# Altium schematic sheet 03_Power_a_Connector.SchDoc
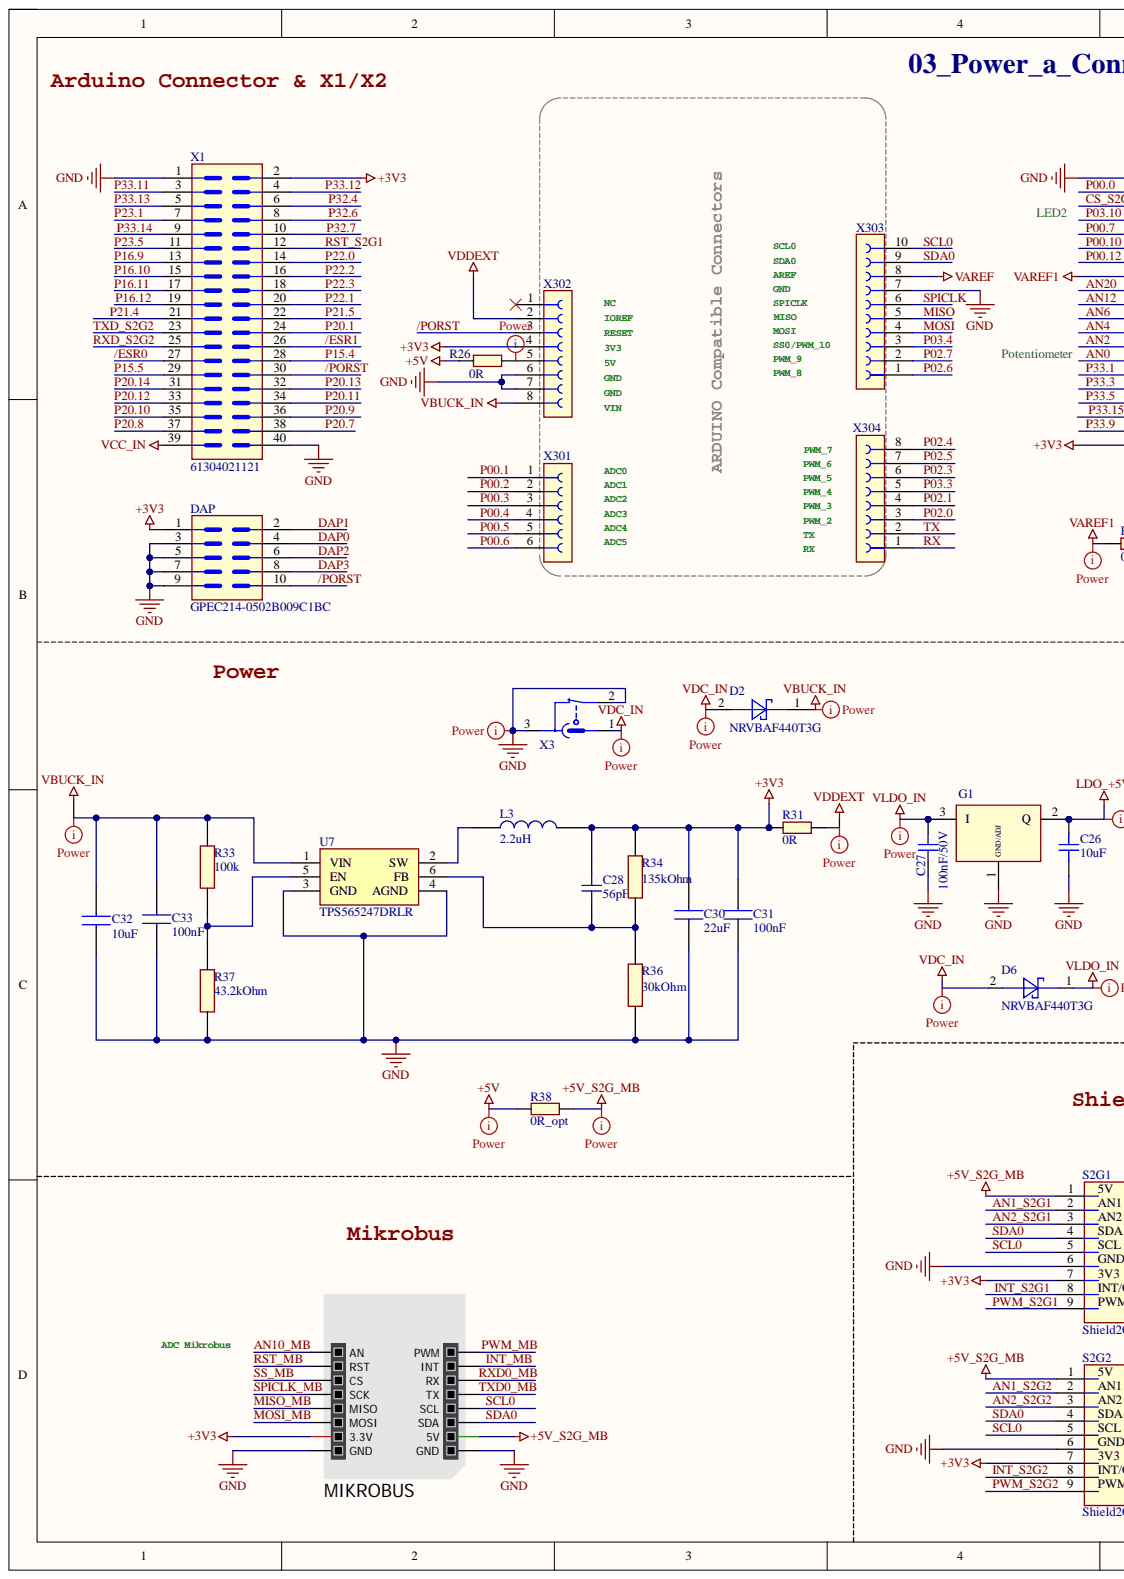
[diagram p0: 03_Power_a_Connector.SchDoc, left]
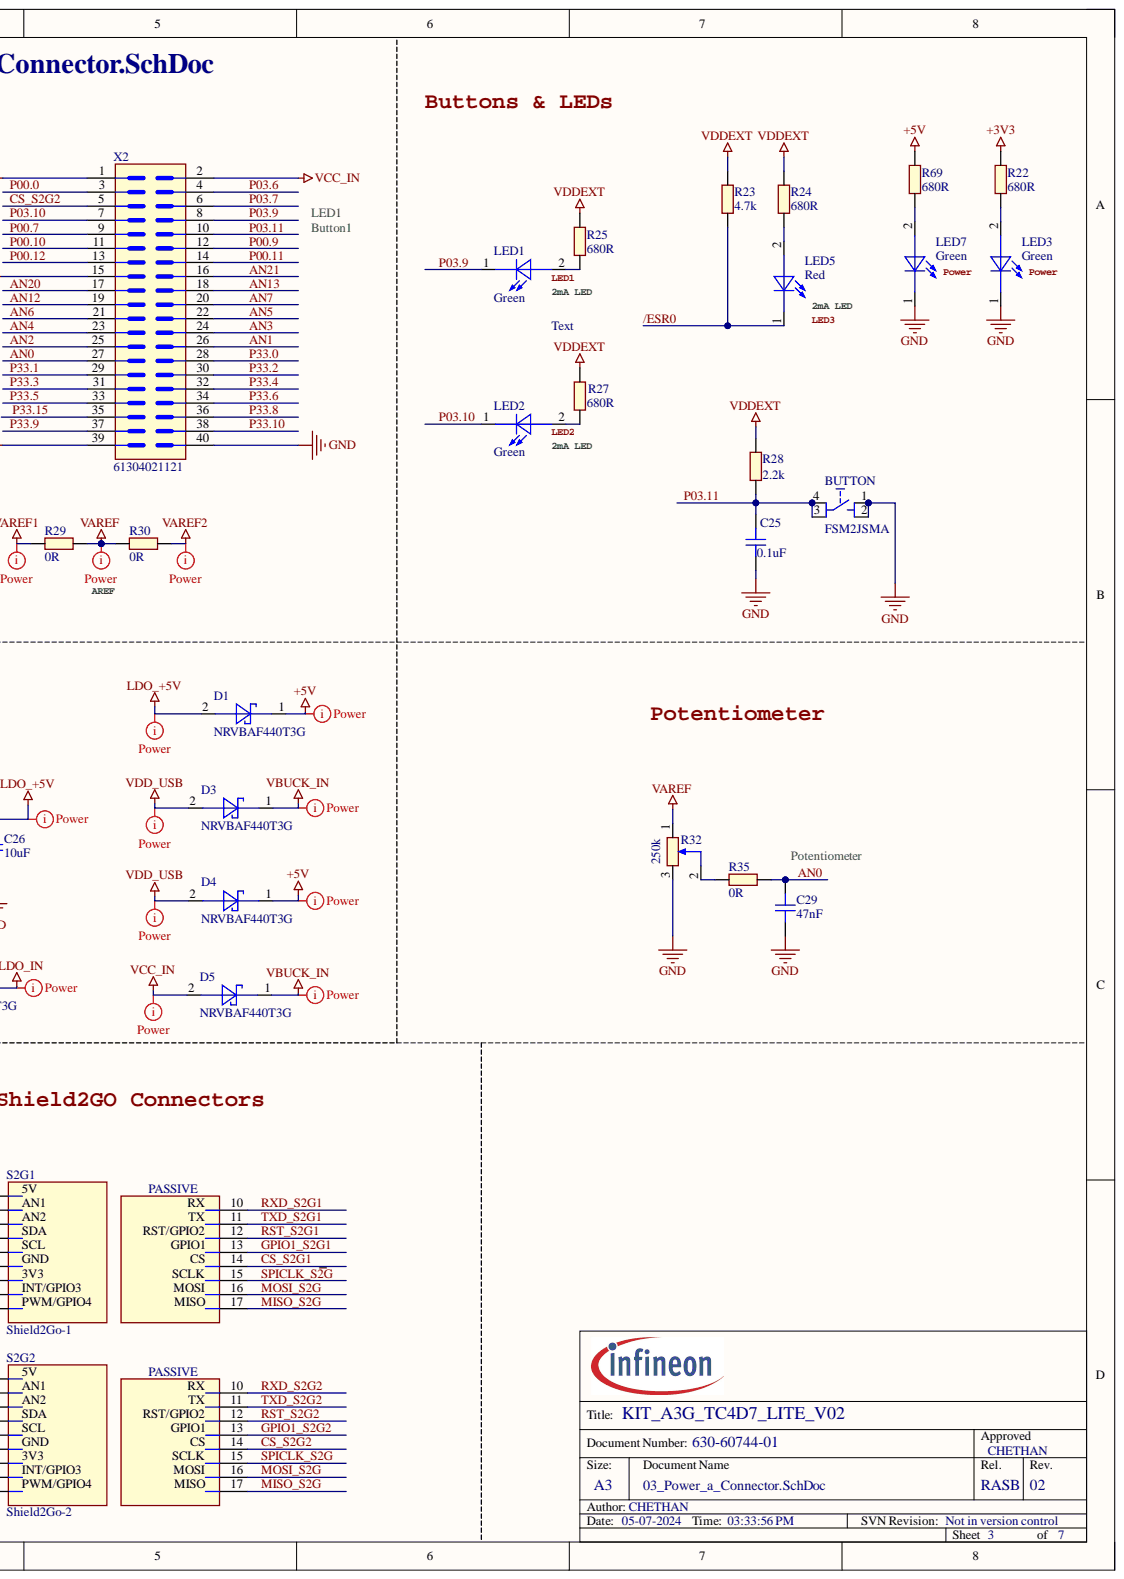
[diagram p1: 03_Power_a_Connector.SchDoc, right]

## Parts
BUTTON  FSM2JSMA  [FSM2JSMA]
C25  0.1uF  [CAPC1005X55N-1]
C26  10uF  [CAPC1005X70N]
C27  100nF/50V  [CAPC1608X90N-4]
C28  56pF  [CAPC1005X55N-0]
C29  47nF  [CAPC1608X90N-4]
C30  22uF  [CAPC2012X145N]
C31  100nF  [CAPC1608X90N]
C32  10uF  [CAPC2012X145N_1]
C33  100nF  [CAPC1608X90N]
D1  NRVBAF440T3G  [SODFL5126X110N]
D2  NRVBAF440T3G  [SODFL5126X110N]
D3  NRVBAF440T3G  [SODFL5126X110N]
D4  NRVBAF440T3G  [SODFL5126X110N]
D5  NRVBAF440T3G  [SODFL5126X110N]
D6  NRVBAF440T3G  [SODFL5126X110N]
DAP  GPEC214-0502B009C1BC  [CON-M-SMD-GPEC214-0502B009C1BC]
G1  TLE4284DV50ATMA1  [INF-PG-TO252-3-11_N]  (value not drawn)
L3  2.2uH  [INDPM6965X300N]
LED1  Green  Type: LED  [LED-SMD-LG L29K-XXXX-24]
LED2  Green  Type: LED  [LED-SMD-LG L29K-XXXX-24]
LED3  Green  [LED-SMD-LG L29K-XXXX-24]
LED5  Red  Type: LED  [LEDSC160X80X80-2N]
LED7  Green  [LED-SMD-LG L29K-XXXX-24]
MIKROBUS  MIKROBUS HOST CONN.  [MIKROBUS HOST CONN.]  (value not drawn)
R22  680R  [RESC1005X40N]
R23  4.7k  [RESC1005X40N-1]
R24  680R  [RESC1005X40N]
R25  680R  [RESC1005X40N]
R26  0R  [RESC1609X50N]
R27  680R  [RESC1005X40N]
R28  2.2k  [RESC1005X40N]
R29  0R  [RESC1609X50N]
R30  0R  [RESC1609X50N]
R31  0R  [RESC1609X50N]
R32  250k  [TRIM_3352T-1-254LF]
R33  100k  [RESC1005X40N]
R34  135kOhm  [RESC1608X55N]
R35  0R  [RESC1609X50N]
R36  30kOhm  [RESC1608X55N]
R37  43.2kOhm  [RESC1005X40N]
R38  0R_opt  [RESC1609X50N]
R69  680R  [RESC1005X40N]
S2G1  Shield2Go-1  [Shield2Go_S2G_1P]  (no BOM)
S2G2  Shield2Go-2  [Shield2Go_S2G_1P]  (no BOM)
U7  TPS565247DRLR  [SOTFL50P160X60-6N]
X1  61304021121  [CON-M-THT-68691-440HLF]
X2  61304021121  [CON-M-THT-68691-440HLF]
X3  con_pwr13_ncc32_3p-A  [CON-THT-JACK-FC681465P]  (value not drawn)
X301  Socket 6  [CON-F-THT-SSQ-106-03-G-S]  (value not drawn)
X302  Socket 8  [CON-F-THT-PPTC081LFBN-RC]  (value not drawn)
X303  PPTC101LFBN-RC  [CON-F-THT-SSQ-110-03-F-S]  (value not drawn)
X304  Socket 8  [CON-F-THT-PPTC081LFBN-RC]  (value not drawn)

## Nets
+3V3: C28.2 C30.2 C31.2 DAP.1 L3.2 MIKROBUS.7(3.3V) R22.2 R31.1 R34.2 S2G1.7 S2G2.7 X1.2 X2.39 X302.4
+5V: D1.1 D4.1 R26.1 R38.1 R69.2
+5V_S2G_MB: MIKROBUS.10(5V) R38.2 S2G1.1 S2G2.1
GND: BUTTON.1 BUTTON.2 C25.1 C26.1 C27.2 C29.1 C30.1 C31.1 C32.1 C33.1 DAP.3 DAP.5 DAP.7 DAP.9 G1.1 LED3.1 LED7.1 MIKROBUS.8(GND) MIKROBUS.9(GND) R32.3 R36.1 R37.1 S2G1.6 S2G2.6 U7.3(GND) U7.4(AGND) X1.1 X1.40 X2.1 X2.40 X3.2 X3.3 X302.6 X302.7 X303.7
LDO_+5V: C26.2 D1.2 G1.2
VAREF: R29.1 R30.1 R32.1 X303.8
VAREF1: R29.2 X2.15
VAREF2: R30.2
VBUCK_IN: C32.2 C33.2 D2.1 D3.1 D5.1 R33.2 U7.1(VIN) X302.8
VCC_IN: D5.2 X1.39 X2.2
VDC_IN: D2.2 D6.2 X3.1
VDD_USB: D3.2 D4.2
VDDEXT: R23.2 R24.1 R25.2 R27.2 R28.2 R31.2 X302.2
VLDO_IN: C27.1 D6.1 G1.3
/ESR0: LED5.1 R23.1 X1.27
/ESR1: X1.26
/PORST: DAP.10 X1.30 X302.3
AN0: C29.2 R35.2 X2.27
AN1: X2.26
AN1_S2G1: S2G1.2
AN1_S2G2: S2G2.2
AN2: X2.25
AN2_S2G1: S2G1.3
AN2_S2G2: S2G2.3
AN3: X2.24
AN4: X2.23
AN5: X2.22
AN6: X2.21
AN7: X2.20
AN10_MB: MIKROBUS.1(AN)
AN12: X2.19
AN13: X2.18
AN20: X2.17
AN21: X2.16
CS_S2G1: S2G1.14
CS_S2G2: S2G2.14 X2.5
DAP0: DAP.4
DAP1: DAP.2
DAP2: DAP.6
DAP3: DAP.8
GPIO1_S2G1: S2G1.13
GPIO1_S2G2: S2G2.13
INT_MB: MIKROBUS.15(INT)
INT_S2G1: S2G1.8
INT_S2G2: S2G2.8
MISO: X303.5
MISO_MB: MIKROBUS.5(MISO)
MISO_S2G: S2G1.17 S2G2.17
MOSI: X303.4
MOSI_MB: MIKROBUS.6(MOSI)
MOSI_S2G: S2G1.16 S2G2.16
P00.0: X2.3
P00.1: X2.11 X301.1  [also labelled P00.10]
P00.2: X301.2
P00.3: X301.3
P00.4: X301.4
P00.5: X301.5
P00.6: X301.6
P00.7: X2.9
P00.9: X2.12
P00.11: X2.14
P00.12: X2.13
P02.0: X304.3
P02.1: X304.4
P02.3: X304.6
P02.4: X304.8
P02.5: X304.7
P02.6: X303.1
P02.7: X303.2
P03.3: X304.5
P03.4: X303.3
P03.6: X2.4
P03.7: X2.6
P03.9: LED1.1 X2.8
P03.10: LED2.1 X2.7
P03.11: BUTTON.3 BUTTON.4 C25.2 R28.1 X2.10
P15.4: X1.28
P15.5: X1.29
P16.9: X1.13
P16.10: X1.15
P16.11: X1.17
P16.12: X1.19
P20.1: X1.24 X1.35  [also labelled P20.10]
P20.7: X1.38
P20.8: X1.37
P20.9: X1.36
P20.11: X1.34
P20.12: X1.33
P20.13: X1.32
P20.14: X1.31
P21.4: X1.21
P21.5: X1.22
P22.0: X1.14
P22.1: X1.20
P22.2: X1.16
P22.3: X1.18
P23.1: X1.7
P23.5: X1.11
P32.4: X1.6
P32.6: X1.8
P32.7: X1.10
P33.0: X2.28
P33.1: X2.29 X2.38  [also labelled P33.10]
P33.2: X2.30
P33.3: X2.31
P33.4: X2.32
P33.5: X2.33
P33.6: X2.34
P33.8: X2.36
P33.9: X2.37
P33.11: X1.3
P33.12: X1.4
P33.13: X1.5
P33.14: X1.9
P33.15: X2.35
PWM_MB: MIKROBUS.16(PWM)
PWM_S2G1: S2G1.9
PWM_S2G2: S2G2.9
RST_MB: MIKROBUS.2(RST)
RST_S2G1: S2G1.12 X1.12
RST_S2G2: S2G2.12
RX: X304.1
RXD0_MB: MIKROBUS.14(RX)
RXD_S2G1: S2G1.10
RXD_S2G2: S2G2.10 X1.25
SCL0: MIKROBUS.12(SCL) S2G1.5 S2G2.5 X303.10
SDA0: MIKROBUS.11(SDA) S2G1.4 S2G2.4 X303.9
SPICLK: X303.6
SPICLK_MB: MIKROBUS.4(SCK)
SPICLK_S2G: S2G1.15 S2G2.15
SS_MB: MIKROBUS.3(CS)
TX: X304.2
TXD0_MB: MIKROBUS.13(TX)
TXD_S2G1: S2G1.11
TXD_S2G2: S2G2.11 X1.23
NetC28_1: C28.1 R34.1 R36.2 U7.6(FB)
NetL3_1: L3.1 U7.2(SW)
NetLED1_2: LED1.2 R25.1
NetLED2_2: LED2.2 R27.1
NetLED3_2: LED3.2 R22.1
NetLED5_2: LED5.2 R24.2
NetLED7_2: LED7.2 R69.1
NetR26_2: R26.2 X302.5
NetR32_2: R32.2 R35.1
NetR33_1: R33.1 R37.2 U7.5(EN)

Not connected: X302 1

## Library data of the parts
BUTTON = 376475: Tactile Switch SPST-NO Top Actuated Surface Mount; Legacy PartNumber: SW0000003; Manufacturer: TE Connectivity ALCOSWITCH Switches; Manufacturer Component Status: Active; Automotive Qualified: No; Legacy DeviceID: TEC_FSM2JSMA; Second Source allowed: Yes; Style: Not Applicable; Version Number: V01; Voltage DC (max): na; Article Number: 376475; Component Kind: Standard; ComponentLink1Description: Vendor WebSite; ComponentLink1URL: http://www.tycoelectronics.com/; ComponentLink2Description: Datasheet; ComponentLink2URL: http://webnetprod.muc.infineon.com/pcbportal_prod/WorkFlows/AltiumComponent/StandardDocumentation?Versionid=376476; Manufacturer Order Number: FSM2JSMA; Package Description: Switch,Push button ,4.5mm Pitch,Vertical, 6mm L X 6mm W X 5.4mm H body; Part Type: Electro Mechanic; PLMStatus: Active; RoHS Compliant: Y; Supplier 1: Farnell; Supplier 2: DigiKey; Supplier 3: Mouser; Supplier Part Number 1: 3801287; Supplier Part Number 2: 450-1130-ND; Supplier Part Number 3: 506-FSM2JSMA; Temperature max: 85; Temperature min: -35; Temperature Range: Military; Value: FSM2JSMA; Library Name: AURIX_Lite_Kit_V2.0.SCHLIB
C25 = 308680: 0.1 µF ±10% 16V Ceramic Capacitor X7R 0402 (1005 Metric); Manufacturer: TDK Corporation; Manufacturer Component Status: Active; Manufacturer Order Number: CGA2B1X7R1C104K050BC; Multiple Parts: No; Alternate View: Yes; Automotive Qualified: AEC Q200; Combined Parameters: TC:X7R (EIA);Tol.:-10%/+10%;Vdc:16V; Legacy DeviceID: CAP01010669; Package Imperial: 0402; Package Metric: 1005; QuickPick: 100nF / 16V / 10% / X7R (EIA) / 0402 (1005); Style: Single; Symbol Type: Capacitor; Single; Standard; 2 Pins; Type: Standard; Value: 100nF; Version Number: V01; Article Number: 308680; Component Kind: Standard; ComponentLink1Description: Vendor WebSite; ComponentLink1URL: http://www.tdk.com; ComponentLink2Description: Datasheet; ComponentLink2URL: http://webnetprod.muc.infineon.com/PCBPortal_Prod/WorkFlows/AltiumComponent/StandardDocumentation?Versionid=308681; Legacy PartNumber: CAP01010669; Package Description: Capacitor,non-polarized,Chip;1.00mm L X 0.50mm W X 0.55mm H; PackageReference: 0402 (1005); Part Type: Capacitor; PLMStatus: Active; ROHS Compliant: Y; Second Source allowed: Yes; Supplier 1: Farnell; Supplier 2: DigiKey; Supplier 3: Mouser; Supplier Part Number 1: 2458205; Supplier Part Number 2: 445-5613-1-ND; Supplier Part Number 3: 810-CGA2B1X7R1C104K; Technology: Ceramic; Temperature max: 125; Temperature min: -55; Temperature Range: Military; Tolerance Neg: 10%; Tolerance Pos: 10%; Voltage DC (max): 16V; Library Name: AURIX_Lite_Kit_V2.0.SCHLIB
C26 = 310655: 10 µF ±20% 10V Ceramic Capacitor X5R 0402 (1005 Metric); Manufacturer: Samsung; Manufacturer Component Status: Active; Manufacturer Order Number: CL05A106MP5NUNC; Alternate View: Yes; Automotive Qualified: No; Combined Parameters: TC:X5R (EIA);Tol.:-20%/+20%;Vdc:10V; Legacy DeviceID: CAP01010925; Package Imperial: 0402; Package Metric: 1005; QuickPick: 10uF / 10V / 20% / X5R (EIA) / 0402; Style: Single; Symbol Type: Capacitor; Single; Standard; 2 Pins; Type: Standard; Version Number: V01; Article Number: 310655; Component Kind: Standard; ComponentLink1Description: Vendor WebSite; ComponentLink1URL: http://www.samsungsem.co.kr/; ComponentLink2Description: Datasheet; ComponentLink2URL: http://webnetprod.muc.infineon.com/PCBPortal_Prod/WorkFlows/AltiumComponent/StandardDocumentation?Versionid=310656; Legacy PartNumber: CAP01010925; Multiple Parts: No; Package Description: Capacitor,non-polarized,Chip;1.00mm L X 0.50mm W X 0.70mm H; PackageReference: 0402; Part Type: Capacitor; PLMStatus: Active; ROHS Compliant: Y; Second Source allowed: Yes; Supplier 1: Farnell; Supplier 2: DigiKey; Supplier 3: Mouser; Supplier Part Number 2: 1276-1450-1-ND; Supplier Part Number 3: Not Assigned; Technology: Ceramic; Temperature max: 85; Temperature min: -55; Temperature Range: Military; Tolerance Neg: 20%; Tolerance Pos: 20%; Value: 10uF; Voltage DC (max): 10V; Library Name: AURIX_Lite_Kit_V2.0.SCHLIB
C27 = 497792: 0.1 µF ±20% 100V Ceramic Capacitor X7S 0603 (1608 Metric); Manufacturer: TDK Corporation; Manufacturer Order Number: CGA3E3X7S2A104M080AB; Multiple Parts: No; Alternate View: Yes; Automotive Qualified: AEC Q200; Combined Parameters: TC:X7S (EIA);Tol.:-20%/+20%;Vdc:100V; Package Imperial: 0603; Package Metric: 1608; PLMStatus: Active; QuickPick: 100nF / 100V / 20% / X7S (EIA) / 0603; Style: Single; Symbol Type: Capacitor; Single; Standard; 2 Pins; Type: Standard; Value: 100nF; Version Number: V01; Application: Standard; Article Number: 497792; Component Kind: Standard; ComponentLink1Description: Vendor WebSite; ComponentLink1URL: http://www.tdk.com; ComponentLink2Description: Datasheet; ComponentLink2URL: http://webnetprod.muc.infineon.com/PCBPortal_Prod/WorkFlows/AltiumComponent/StandardDocumentation?Versionid=497793; Package Description: Capacitor,non-polarized,Chip;1.60mm L X 0.80mm W X 0.90mm H; PackageReference: 0603; Part Type: Capacitor; ROHS Compliant: Y; Second Source allowed: Yes; Supplier 1: Farnell; Supplier 2: DigiKey; Supplier 3: Mouser; Supplier Part Number 1: 2803337; Supplier Part Number 2: 445-12576-1-ND; Supplier Part Number 3: 810-CGA3E3X7S2A104AB; Technology: Ceramic; Temperature max: 125; Temperature min: -55; Temperature Range: Military; Tolerance Neg: 20%; Tolerance Pos: 20%; Voltage DC (max): 100V; Library Name: AURIX_Lite_Kit_V2.0.SCHLIB
C28 = 289241: 56 pF ±5% 50V Ceramic Capacitor C0G, NP0 0402 (1005 Metric); Manufacturer: Taiyo Yuden; Manufacturer Order Number: UMK105CG560JV-F; Multiple Parts: No; Alternate View: Yes; Automotive Qualified: AEC Q200; Combined Parameters: TC:X7R (EIA);Tol.:-10%/+10%;Vdc:25V; ComponentStatus: Released; Legacy DeviceID: CAP01006921; Package Imperial: 0402; Package Metric: 1005; PLMStatus: Active; QuickPick: CAP CER 56PF 50V C0G/NP0 0402; Style: Single; Symbol Type: Capacitor; Single; Standard; 2 Pins; Type: Standard; Version Number: V01; Application: Standard; Article Number: 289241; Component Kind: Standard; ComponentLink1Description: Vendor WebSite; ComponentLink1URL: http://www.murata.com/; ComponentLink2Description: Datasheet; ComponentLink2URL: http://webnetprod.muc.infineon.com/PCBPortal_Prod/WorkFlows/AltiumComponent/StandardDocumentation?Versionid=289242; Legacy PartNumber: CAP01006921; Library Name: TriBoard_TC4X7_COM_V20.SCHLIB; Package Description: Capacitor,non-polarized,Chip;1.00mm L X 0.50mm W X 0.55mm H; PackageReference: CAPC1005X55N-0; Part Type: Capacitor; ROHS Compliant: Y; Second Source allowed: Yes; Supplier 1: Farnell; Supplier 2: DigiKey; Supplier 3: Mouser; Supplier Part Number 2: 587-1208-1-ND; Technology: Ceramic; Temperature max: 125; Temperature min: -55; Temperature Range: Military; Tolerance Neg: 5%; Tolerance Pos: 5%; Value: 56pF; Voltage DC (max): 50V
C29 = 309989: 0.047 µF ±10% 50V Ceramic Capacitor X7R 0603 (1608 Metric); Manufacturer: TDK Corporation; Manufacturer Component Status: Active; Manufacturer Order Number: CGA3E2X7R1H473K080AA; Alternate View: Yes; Automotive Qualified: No; Combined Parameters: TC:X8R (EIA);Tol.:-10%/+10%;Vdc:50V; Legacy DeviceID: CAP01010836; Package Imperial: 0603; Package Metric: 1608; QuickPick: 47nF / 50V / 10% / X8R (EIA) / 0603 (1608); Style: Single; Symbol Type: Capacitor; Single; Standard; 2 Pins; Type: Standard; Version Number: V01; Article Number: 309989; Component Kind: Standard; ComponentLink1Description: Vendor WebSite; ComponentLink1URL: http://www.tdk.com; ComponentLink2Description: Datasheet; ComponentLink2URL: http://webnetprod.muc.infineon.com/PCBPortal_Prod/WorkFlows/AltiumComponent/StandardDocumentation?Versionid=309990; Legacy PartNumber: CAP01010836; Multiple Parts: No; Package Description: Capacitor,non-polarized,Chip;1.60mm L X 0.80mm W X 0.90mm H; PackageReference: 0603 (1608); Part Type: Capacitor; PLMStatus: Active; ROHS Compliant: Y; Second Source allowed: Yes; Supplier 1: Farnell; Supplier 2: DigiKey; Supplier 3: Mouser; Supplier Part Number 1: 2354114; Supplier Part Number 2: 445-5664-1-ND; Supplier Part Number 3: 810-C1608X8R1H473K; Technology: Ceramic; Temperature max: 150; Temperature min: -55; Temperature Range: Military; Tolerance Neg: 10%; Tolerance Pos: 10%; Value: 47nF; Voltage DC (max): 50V; Library Name: AURIX_Lite_Kit_V2.0.SCHLIB
C30 = GRM21BR61A226ME44L: 22 µF ±20% 10V Ceramic Capacitor X5R 0805 (2012 Metric); Manufacturer: Murata Electronics; Geometry.Height: 1.45mm; Arrow Part Number: GRM21BR61A226ME44L; Arrow Price/Stock: https://www.arrow.com/en/products/grm21br61a226me44l/murata-manufacturing?region=nac; Component Kind: Standard; Datasheet Link: https://datasheet.datasheetarchive.com/originals/distributors/DKDS-10/192043.pdf; Library Name: GRM21BR61A226ME44L.SchLib; Manufacturer Order Number: GRM21BR61A226ME44L; Manufacturer_Name: Murata Electronics; Manufacturer_Part_Number: GRM21BR61A226ME44L; Mouser Part Number: 81-GRM21BR61A226ME4L; Mouser Price/Stock: https://www.mouser.co.uk/ProductDetail/Murata-Electronics/GRM21BR61A226ME44L?qs=eeBpzGFlv%252B8tSfxib2AMhw%3D%3D; Supplier Part Number 2: 490-10746-1-ND; Value: 22UF
C31 C33 = GRM188R71E104KA01D: 0.1 µF ±10% 25V Ceramic Capacitor X7R 0603 (1608 Metric); Manufacturer: Murata Electronics; Manufacturer Order Number: GCJ188R71E104KA12D; Geometry.Height: 0.9mm; Value: 0.1uF; Arrow Part Number: GRM188R71E104KA01D; Arrow Price/Stock: https://www.arrow.com/en/products/grm188r71e104ka01d/murata-manufacturing; Component Kind: Standard; Datasheet Link: https://datasheet.datasheetarchive.com/originals/distributors/Datasheets_SAMA/ec4f1577dddcc7a13ddad897a28002d3.pdf; Library Name: GRM188R71E104KA01D.SchLib; Manufacturer_Name: Murata Electronics; Manufacturer_Part_Number: GRM188R71E104KA01D; Mouser Part Number: 81-GRM39X104K25; Mouser Price/Stock: https://www.mouser.co.uk/ProductDetail/Murata-Electronics/GRM188R71E104KA01D?qs=kZszf6EFsb%252BcS4JW42Ze0A%3D%3D; Supplier Part Number 2: 490-16477-1-ND
C32 = GRM21BR61E106MA73L: 10 µF ±20% 25V Ceramic Capacitor X5R 0805 (2012 Metric); Manufacturer: Murata Electronics; Geometry.Height: 1.45mm; Arrow Part Number: GRM21BR61E106MA73L; Arrow Price/Stock: https://www.arrow.com/en/products/grm21br61e106ma73l/murata-manufacturing?region=nac; Component Kind: Standard; Datasheet Link: https://datasheet.datasheetarchive.com/originals/distributors/DKDS-10/192063.pdf; Library Name: GRM21BR61E106MA73L.SchLib; Manufacturer Order Number: GRM21BR61E106MA73L; Manufacturer_Name: Murata Electronics; Manufacturer_Part_Number: GRM21BR61E106MA73L; Mouser Part Number: 81-GRM21BR61E106MA3L; Mouser Price/Stock: https://www.mouser.co.uk/ProductDetail/Murata-Electronics/GRM21BR61E106MA73L?qs=2W5sgKM%2F371odLMyCilkag%3D%3D; Supplier Part Number 2: 490-10748-1-ND; Value: 10uF
D1-D6 = NRVBAF440T3G: Diode 40 V 4A Surface Mount SMA-FL; Manufacturer: onsemi; Geometry.Height: 1.1mm; Arrow Part Number: NRVBAF440T3G; Arrow Price/Stock: https://www.arrow.com/en/products/nrvbaf440t3g/on-semiconductor?region=nac; Component Kind: Standard; Datasheet Link: https://componentsearchengine.com/Datasheets/1/NRVBAF440T3G.pdf; Library Name: NRVBAF440T3G.SchLib; Manufacturer Order Number: NRVBAF440T3G; Manufacturer_Name: onsemi; Manufacturer_Part_Number: NRVBAF440T3G; Mouser Part Number: 863-NRVBAF440T3G; Mouser Price/Stock: https://www.mouser.co.uk/ProductDetail/onsemi/NRVBAF440T3G?qs=LpQOCaTXNHgp7VR7V4bOPA%3D%3D; Supplier Part Number 2: NRVBAF440T3GOSCT-ND; Supplier Part Number 3: 863-NRVBAF440T3G
DAP = 591835: 1.27 Pin Header Dual Rows Smt Type,  Brass, 1 Amp, 1000 Mohm min, 300vAC; Manufacturer: Greenconn Corporation; Manufacturer Component Status: Active; Automotive Qualified: No; Combined Parameters: Board-to-Board Header (male);5x2;Mounting:SMT;Orient:0Â° (vertical);Shielded:-; Legacy DeviceID: GC_GPEC214-0502B009C1BC; QuickPick: 0Â° (vertical) / Board-to-Board; Second Source allowed: Yes; Style: Strip; Version Number: V01; Voltage DC (max): na; Article Number: 591835; Component Kind: Standard; ComponentLink1Description: Vendor WebSite; ComponentLink1URL: http://www.greenconn.com/; ComponentLink2Description: Datasheet; ComponentLink2URL: http://webnetprod.muc.infineon.com/PCBPortal_Prod/WorkFlows/AltiumComponent/StandardDocumentation?Versionid=591836; Manufacturer Order Number: GPEC214-0502B009C1BC; Package Description: Connector, 1.27 mm Pitch; 10 pin, 3.40 mm L X 6.35 mm W X 7.70 mm H body; PackageReference: SMD; Part Type: Connector; PLMStatus: Active; ROHS Compliant: N; Supplier 1: Farnell; Supplier 2: DigiKey; Supplier 3: Mouser; Supplier Part Number 1: 2830427; Temperature max: 105; Temperature min: -40; Temperature Range: Industrial; Type: Board-to-Board; Value: GPEC214-0502B009C1BC; Library Name: AURIX_Lite_Kit_V2.0.SCHLIB
G1 = 420380: Linear Voltage Regulator IC Positive Fixed 1 Output 1A PG-TO252-3-11; Manufacturer: Infineon Technologies; Automotive Qualified: No; Combined Parameters: Linear Not applicable -;Vo:5V to 5V; PLMStatus: Active; QuickPick: Linear / PG-TO252-3-11_N; Second Source allowed: Yes; Style: Not applicable; Symbol type: Linear Voltage Regulator, 4.7 to 40 V Supply, Fixed/Adjustable Output, -40 to 125 degC, PG-TO252-3, Reel, Green; Version Number: V01; Voltage DC (max): na; Article Number: 420380; Component Kind: Standard; ComponentLink1Description: Vendor WebSite; ComponentLink1URL: https://www.infineon.com/; ComponentLink2Description: Datasheet; ComponentLink2URL: http://webnetprod.muc.infineon.com/PCBPortal_Prod/WorkFlows/AltiumComponent/StandardDocumentation?Versionid=420381; Manufacturer Order Number: TLE4284DV50ATMA1; Package Description: DPAK, 3-Leads, Body 6.5x6.12mm, Pitch 2.28mm, IPC Medium Density; Part Type: Power Supply; ROHS Compliant: Y; Supplier 1: Farnell; Supplier 2: DigiKey; Supplier 3: Mouser; Supplier Part Number 2: TLE4284DV50ATMA1CT-ND; Temperature max: 150; Temperature min: -40; Temperature Range: Automotive; Type: Linear; Value: TLE4284DV50ATMA1; Library Name: AURIX_Lite_Kit_V2.0.SCHLIB
L3 = IHLP2525CZER2R2M11: 2.2 µH Shielded Molded Inductor 9 A 16.5mOhm Max Nonstandard; Manufacturer: Vishay; Geometry.Height: 3mm; Value: 2.2 µH; Arrow Part Number: IHLP2525CZER2R2M11; Arrow Price/Stock: https://www.arrow.com/en/products/ihlp2525czer2r2m11/vishay; Component Kind: Standard; Datasheet Link: https://datasheet.datasheetarchive.com/originals/distributors/Datasheets-DGA11/2253392.pdf; Library Name: IHLP2525CZER2R2M11.SchLib; Manufacturer Order Number: IHLP2525CZER2R2M11; Manufacturer_Name: Vishay; Manufacturer_Part_Number: IHLP2525CZER2R2M11; Mouser Part Number: 70-IHLP2525CZER2R2; Mouser Price/Stock: https://www.mouser.co.uk/ProductDetail/Vishay-Dale/IHLP2525CZER2R2M11?qs=QA2a3%252BlgRt8giLLKBhfPow%3D%3D; Supplier Part Number 2: 541-IHLP2525CZER2R2M11CT-ND
LED1-LED3 LED7 = 395008: Green 570nm LED Indication - Discrete 1.7V 0603 (1608 Metric); Manufacturer: OSRAM Opto Semiconductors; Manufacturer Component Status: Active; Manufacturer Order Number: LG L29K-G2J1-24; Automotive Qualified: No; Combined Parameters: Vf:2.2V;If:20mA;Ur:12V;Ir:10nA;Pmax:-; Legacy DeviceID: DIO01300294; QuickPick: LED / 0603; Second Source allowed: Yes; Style: Single; Symbol Type: Standard LED Symbol, 1 Cathode, 2 Anode; Version Number: V01; Voltage DC (max): na; Application: Standard; Article Number: 395008; Component Kind: Standard; ComponentLink1Description: Vendor WebSite; ComponentLink1URL: http://www.osram-os.com; ComponentLink2Description: Datasheet; ComponentLink2URL: http://webnetprod.muc.infineon.com/PCBPortal_Prod/WorkFlows/AltiumComponent/StandardDocumentation?Versionid=395009; Legacy PartNumber: DIO01300294; Package Description: LED;2 pin,1.3mm W X 0.80mm L X 0.67mm H body; PackageReference: 0603; Part Type: Diode; PLMStatus: Active; ROHS Compliant: Y; Supplier 1: Farnell; Supplier 2: DigiKey; Supplier 3: Mouser; Supplier Part Number 2: 475-2709-1-ND; Supplier Part Number 3: 720-LGL29KG2J124Z; Temperature max: 100; Temperature min: -40; Temperature Range: Industrial; Value: Green; Library Name: AURIX_Lite_Kit_V2.0.SCHLIB
  LED3 LED7: Type: LED
LED5 = 494918: Red 633nm LED Indication - Discrete 2V 0603 (1608 Metric); Manufacturer: OSRAM Opto Semiconductors; Manufacturer Component Status: Active; Manufacturer Order Number: LS Q976-NR-1; Automotive Qualified: No; Combined Parameters: Vf:2.5V;If:25mA;Ur:12V;Ir:10uA;Pmax:-; Second Source allowed: Yes; Style: Single; Symbol Type: Standard LED Symbol, 1 Cathode, 2 Anode; Version Number: V01; Voltage DC (max): na; Application: Standard; Article Number: 494918; Component Kind: Standard; ComponentLink1Description: Vendor WebSite; ComponentLink1URL: http://www.osram-os.com; ComponentLink2Description: Datasheet; ComponentLink2URL: http://webnetprod.muc.infineon.com/pcbportal_prod/WorkFlows/AltiumComponent/StandardDocumentation?Versionid=494919; Package Description: LED,Side Concave;2 pin,1.60mm W X 0.80mm L X 0.80mm H; PackageReference: 603; Part Type: Diode; PLMStatus: Active; RoHS Compliant: N; Supplier 1: Farnell; Supplier 2: DigiKey; Supplier 3: Mouser; Supplier Part Number 1: 1226390RL; Supplier Part Number 2: 475-2512-1-ND; Supplier Part Number 3: 720-LGL29KG2J124Z; Temperature max: 85; Temperature min: -30; Temperature Range: Military; Value: Red; Library Name: AURIX_Lite_Kit_V2.0.SCHLIB
MIKROBUS = MIKROBUS HOST CONN._1: mikroBUS Host Socket; Manufacturer Order Number: PPTC081LFBN-RC; Component Kind: Standard; SMD: No; Supplier Part Number 2: S7006-ND; Library Name: AURIX_Lite_Kit_V2.0.SCHLIB
R22 = 341502: 680 Ohms ±1% 0.063W, 1/16W Chip Resistor 0402 (1005 Metric) Automotive AEC-Q200 Thick Film; Manufacturer: Stackpole Electronics Inc; Manufacturer Order Number: RMCF0402FT680R; Multiple Parts: No; Alternate View: Yes; Automotive Qualified: AEC Q200; Combined Parameters: TCR:100ppm/K;Tol.:-1%/+1%;Vdc:50V;Idc:-;P:63mW; Legacy DeviceID: RES01800324; PLMStatus: Active; QuickPick: 680R / 1% / 0.0630 / 0402; Style: Single; Symbol Type: Resistor; Single; Standard; 2 Pins; Version Number: V01; Voltage DC (max): 50V; Article Number: 341502; Component Kind: Standard; ComponentLink1Description: Vendor WebSite; ComponentLink1URL: http://www.vishay.com; ComponentLink2Description: Datasheet; ComponentLink2URL: http://webnetprod.muc.infineon.com/PCBPortal_Prod/WorkFlows/AltiumComponent/StandardDocumentation?Versionid=341503; Legacy PartNumber: RES01800324; PackageReference: 0402; Part Type: Resistor; ROHS Compliant: Y; Second Source allowed: Yes; Supplier 1: Farnell; Supplier 2: DigiKey; Supplier 3: Mouser; Supplier Part Number 2: RMCF0402FT680RCT-ND; Supplier Part Number 3: 71-CRCW0402-680-E3; Technology: Thick Film; Temperature max: 155; Temperature min: -55; Temperature Range: Military; Tolerance Neg: 1%; Tolerance Pos: 1%; Type: Standard; Value: 680R; Library Name: AURIX_Lite_Kit_V2.0.SCHLIB
R23 = 327541: 4.7 kOhms ±1% 0.063W, 1/16W Chip Resistor 0402 (1005 Metric) Moisture Resistant Thick Film; Manufacturer: Yageo; Manufacturer Component Status: Active; Manufacturer Order Number: RC0402FR-074K7L; Alternate View: Yes; Automotive Qualified: No; Combined Parameters: TCR:100ppm/K;Tol.:-1%/+1%;Vdc:50V;Idc:-;P:63mW; Package Imperial: 0402; Package Metric: 1005; QuickPick: 4.7k / 1% / 0.0630 / 0402; Style: Single; Symbol Type: Resistor; Single; Standard; 2 Pins; Version Number: V01; Voltage DC (max): 50V; Application: Standard; Article Number: 327541; Component Kind: Standard; ComponentLink1Description: Vendor WebSite; ComponentLink1URL: http://www.yageo.com/; ComponentLink2Description: Datasheet; ComponentLink2URL: http://webnetprod.muc.infineon.com/PCBPortal_Prod/WorkFlows/AltiumComponent/StandardDocumentation?Versionid=327542; Multiple Parts: No; Package Description: Resistor,Chip;1.00mm L X 0.50mm W X 0.40mm H; PackageReference: 0402; Part Type: Resistor; PLMStatus: Active; ROHS Compliant: Y; Second Source allowed: Yes; Supplier 1: Farnell; Supplier 2: DigiKey; Supplier 3: Mouser; Supplier Part Number 1: 9239316; Supplier Part Number 2: 311-4.7KLRCT-ND; Supplier Part Number 3: 603-RC0402FR-074K7L; Technology: Thick Film; Temperature max: 155; Temperature min: -55; Temperature Range: Military; Tolerance Neg: 1%; Tolerance Pos: 1%; Type: Standard; Value: 4.7k; Library Name: AURIX_Lite_Kit_V2.0.SCHLIB
R24 R25 R27 R69 = 341502: 680 Ohms ±1% 0.063W, 1/16W Chip Resistor 0402 (1005 Metric) Automotive AEC-Q200 Thick Film; Manufacturer: Vishay; Manufacturer Order Number: RMCF0402FT680R; Multiple Parts: No; Alternate View: Yes; Automotive Qualified: AEC Q200; Combined Parameters: TCR:100ppm/K;Tol.:-1%/+1%;Vdc:50V;Idc:-;P:63mW; Legacy DeviceID: RES01800324; PLMStatus: Active; QuickPick: 680R / 1% / 0.0630 / 0402; Style: Single; Symbol Type: Resistor; Single; Standard; 2 Pins; Version Number: V01; Voltage DC (max): 50V; Article Number: 341502; Component Kind: Standard; ComponentLink1Description: Vendor WebSite; ComponentLink1URL: http://www.vishay.com; ComponentLink2Description: Datasheet; ComponentLink2URL: http://webnetprod.muc.infineon.com/PCBPortal_Prod/WorkFlows/AltiumComponent/StandardDocumentation?Versionid=341503; Legacy PartNumber: RES01800324; PackageReference: 0402; Part Type: Resistor; ROHS Compliant: Y; Second Source allowed: Yes; Supplier 1: Farnell; Supplier 2: DigiKey; Supplier 3: Mouser; Supplier Part Number 2: RMCF0402FT680RCT-ND; Supplier Part Number 3: 71-CRCW0402-680-E3; Technology: Thick Film; Temperature max: 155; Temperature min: -55; Temperature Range: Military; Tolerance Neg: 1%; Tolerance Pos: 1%; Type: Standard; Value: 680R; Library Name: AURIX_Lite_Kit_V2.0.SCHLIB
R26 R29-R31 R35 = 343882: 0 Ohms Jumper Chip Resistor 0603 (1608 Metric) Automotive AEC-Q200, Pulse Withstanding Thick Film; Manufacturer: Vishay; Manufacturer Order Number: CRCW06030000Z0EAHP; Multiple Parts: No; Alternate View: Yes; Automotive Qualified: AEC Q200; Combined Parameters: TCR:-;Tol.:-0R/+0R;Vdc:75V;Idc:2A;P:-; Legacy DeviceID: RES01800800; PLMStatus: Active; QuickPick: 0R / 0R / 0603; Style: Single; Symbol Type: Resistor; Single; Standard; 2 Pins; Version Number: V01; Voltage DC (max): 75V; Article Number: 343882; Component Kind: Standard; ComponentLink1Description: Vendor WebSite; ComponentLink1URL: http://www.vishay.com; ComponentLink2Description: Datasheet; ComponentLink2URL: http://webnetprod.muc.infineon.com/PCBPortal_Prod/WorkFlows/AltiumComponent/StandardDocumentation?Versionid=343883; Legacy PartNumber: RES01800800; PackageReference: 0603; Part Type: Resistor; ROHS Compliant: Y; Second Source allowed: Yes; Supplier 1: Farnell; Supplier 2: DigiKey; Supplier 3: Mouser; Supplier Part Number 2: 541-0.0SBCT-ND; Supplier Part Number 3: 71-CRCW06030000Z0EAH; Technology: Thick Film; Temperature max: 155; Temperature min: -55; Temperature Range: Military; Tolerance Neg: 0R; Tolerance Pos: 0R; Type: Standard; Value: 0R; Library Name: AURIX_Lite_Kit_V2.0.SCHLIB
R28 = 341777: 2.2 kOhms ±1% 0.063W, 1/16W Chip Resistor 0402 (1005 Metric) Automotive AEC-Q200 Thick Film; Manufacturer: Vishay; Manufacturer Component Status: Active; Manufacturer Order Number: CRCW04022K20FKED; Multiple Parts: No; Alternate View: Yes; Automotive Qualified: AEC Q200; Combined Parameters: TCR:100ppm/K;Tol.:-1%/+1%;Vdc:50V;Idc:-;P:63mW; Legacy DeviceID: RES01800379; QuickPick: 2.2k / 1% / 0.0630 / 0402; Style: Single; Symbol Type: Resistor; Single; Standard; 2 Pins; Version Number: V01; Voltage DC (max): 50V; Article Number: 341777; Component Kind: Standard; ComponentLink1Description: Vendor WebSite; ComponentLink1URL: http://www.vishay.com; ComponentLink2Description: Datasheet; ComponentLink2URL: http://webnetprod.muc.infineon.com/PCBPortal_Prod/WorkFlows/AltiumComponent/StandardDocumentation?Versionid=341778; Legacy PartNumber: RES01800379; PackageReference: 0402; Part Type: Resistor; PLMStatus: Active; ROHS Compliant: Y; Second Source allowed: Yes; Supplier 1: Farnell; Supplier 2: DigiKey; Supplier 3: Mouser; Supplier Part Number 2: 541-2.20KLCT-ND; Technology: Thick Film; Temperature max: 155; Temperature min: -55; Temperature Range: Military; Tolerance Neg: 1%; Tolerance Pos: 1%; Type: Standard; Value: 2.2k; Library Name: AURIX_Lite_Kit_V2.0.SCHLIB
R32 = 440112: 250 kOhms 0.5W, 1/2W Through Hole Thumbwheel Potentiometer Top Adjustment; Manufacturer: Bourns Inc.; Manufacturer Component Status: Active; Manufacturer Order Number: 3352T-1-254LF; Multiple Parts: No; Alternate View: Yes; Legacy DeviceID: RES01806167; Style: Single; Version Number: V01; Voltage DC (max): na; Application: Standard; Component Kind: Standard; ComponentLink2Description: Datasheet; ComponentLink2URL: http://webnetprod.muc.infineon.com/pcbportal_prod/WorkFlows/AltiumComponent/StandardDocumentation?Versionid=440113; Legacy PartNumber: RES01806167; Package Description: Trimmer, 5mm L X 4.5mm W X 3.1mm H Body; PackageReference: SMT; Part Type: Passive Device; PLMStatus: Active; RoHS Compliant: Y; Second Source allowed: Yes; Supplier Part Number 2: 3352T-254LF-ND; Symbol Type: Potentiometer; Temperature max: 125; Temperature min: -55; Temperature Range: Military; Type: Variable; Value: 250k; Library Name: AURIX_Lite_Kit_V2.0.SCHLIB
R33 = CRCW0402100KFKED: 100 kOhms ±1% 0.063W, 1/16W Chip Resistor 0402 (1005 Metric) Automotive AEC-Q200 Thick Film; Manufacturer: Vishay; Geometry.Height: 0.4mm; Arrow Part Number: CRCW0402100KFKED; Arrow Price/Stock: https://www.arrow.com/en/products/crcw0402100kfked/vishay?region=nac; Component Kind: Standard; Datasheet Link: http://www.vishay.com/docs/20035/dcrcwe3.pdf; Library Name: CRCW0402100KFKED.SchLib; Manufacturer Order Number: CRCW0402100KFKED; Manufacturer_Name: Vishay; Manufacturer_Part_Number: CRCW0402100KFKED; Mouser Part Number: 71-CRCW0402-100K-E3; Mouser Price/Stock: https://www.mouser.co.uk/ProductDetail/Vishay-Dale/CRCW0402100KFKED?qs=OlqPY1CiralZGKRwQ4Glcg%3D%3D; Supplier Part Number 2: 541-100KLCT-ND; Value: 100K
R34 = RC0603FR-0730KL: 135 kOhms ±0.1% 0.1W, 1/10W Chip Resistor 0603 (1608 Metric) Thin Film; Manufacturer: YAGEO; Manufacturer Order Number: RT0603BRD07135KL; Geometry.Height: 0.55mm; Component Kind: Standard; Datasheet Link: https://www.yageo.com/upload/media/product/productsearch/datasheet/rchip/PYu-RT_1-to-0.01_RoHS_L_13.pdf; Library Name: RC0603FR-0730KL.SchLib; Manufacturer_Name: YAGEO; Manufacturer_Part_Number: RT0603BRD07135KL; Mouser Part Number: 603-RT0603BRD07135KL; Mouser Price/Stock: https://www.mouser.co.uk/ProductDetail/Yageo/RC0603FR-0730KL?qs=diQw95jMAeNFr44yr782FQ%3D%3D; Supplier 1: Mouser; Supplier 2: Digikey; Supplier Part Number 2: 13-RT0603BRD07135KLCT-ND; Supplier Part Number 3: 603-RT0603BRD07135KL; Value: 135kOhm
R36 = RC0603FR-0730KL: 30 kOhms ±1% 0.1W, 1/10W Chip Resistor 0603 (1608 Metric) Moisture Resistant Thick Film; Manufacturer: YAGEO; Manufacturer_Name: KEMET; Geometry.Height: 0.55mm; Value: 30K; Component Kind: Standard; Datasheet Link: https://datasheet.datasheetarchive.com/originals/distributors/Datasheets_SAMA/902f9e387b938f871d31120f5fc1d65e.pdf; Library Name: RC0603FR-0730KL.SchLib; Manufacturer Order Number: RC0603FR-0730KL; Manufacturer_Part_Number: RC0603FR-0730KL; Mouser Part Number: 603-RC0603FR-0730KL; Mouser Price/Stock: https://www.mouser.co.uk/ProductDetail/Yageo/RC0603FR-0730KL?qs=diQw95jMAeNFr44yr782FQ%3D%3D; Supplier Part Number 2: 311-30.0KHRCT-ND
R37 = CRCW0402100KFKED: 43.2 kOhms ±1% 0.063W, 1/16W Chip Resistor 0402 (1005 Metric) Automotive AEC-Q200 Thick Film; Manufacturer: Vishay Dale; Manufacturer Order Number: CRCW040243K2FKED; Geometry.Height: 0.4mm; QuickPick: 43.2K OHM 1% 1/16W 0402; Value: 43.2k; Component Kind: Standard; Digikey part number: YAG5665CT-ND; Library Name: CRCW0402100KFKED.SchLib; Manufacturer_Name: Vishay Dale; Manufacturer_Part_Number: CRCW040243K2FKED; Supplier 2: DigiKey; Supplier 3: Mouser; Supplier Part Number 2: 541-43.2KLCT-ND; Supplier Part Number 3: 71-CRCW0402-43.2K-E3
R38 = 343882: 0 Ohms Jumper Chip Resistor 0603 (1608 Metric) Automotive AEC-Q200, Pulse Withstanding Thick Film; Manufacturer: Vishay; Manufacturer Order Number: CRCW06030000Z0EAHP; Multiple Parts: No; Alternate View: Yes; Automotive Qualified: AEC Q200; Combined Parameters: TCR:-;Tol.:-0R/+0R;Vdc:75V;Idc:2A;P:-; Legacy DeviceID: RES01800800; PLMStatus: Active; QuickPick: 0R / 0R / 0603; Style: Single; Symbol Type: Resistor; Single; Standard; 2 Pins; Tolerance Neg: 0R; Value: 0R; Version Number: V01; Voltage DC (max): 75V; Article Number: 343882; Component Kind: Standard; ComponentLink1Description: Vendor WebSite; ComponentLink1URL: http://www.vishay.com; ComponentLink2Description: Datasheet; ComponentLink2URL: http://webnetprod.muc.infineon.com/PCBPortal_Prod/WorkFlows/AltiumComponent/StandardDocumentation?Versionid=343883; Legacy PartNumber: RES01800800; PackageReference: 0603; Part Type: Resistor; ROHS Compliant: Y; Second Source allowed: Yes; Supplier 1: Farnell; Supplier 2: DigiKey; Supplier 3: Mouser; Supplier Part Number 2: 541-0.0SBCT-ND; Supplier Part Number 3: 71-CRCW06030000Z0EAH; Technology: Thick Film; Temperature max: 155; Temperature min: -55; Temperature Range: Military; Tolerance Pos: 0R; Type: Standard; Library Name: AURIX_Lite_Kit_V2.0.SCHLIB
S2G1 S2G2 = Shield2Go-S2G-1P: 17 Pin Socket for Shield2Go Boards; Manufacturer: Infineon Technologies; Manufacturer Component Status: Active; AutomotiveQualified: no; ComponentName: S2G-1P; ComponentStatus: Released; ComponentHeight: 0mm; ComponentLink1Description: Vendor WebSite; ComponentLink1URL: http://www.infineon.com; ComponentLink2Description: Datasheet; Library Name: AURIX_Lite_Kit_V2.0.SCHLIB
U7 = TPS565247DRLR: Buck Switching Regulator IC Positive Adjustable 0.6V 1 Output 6A SOT-563, SOT-666; Manufacturer: Texas Instruments; Geometry.Height: 0.6mm; Component Kind: Standard; Datasheet Link: https://www.ti.com/lit/ds/symlink/tps565242.pdf; Library Name: TPS565247DRLR.SchLib; Manufacturer Order Number: TPS565247DRLR; Manufacturer_Name: Texas Instruments; Manufacturer_Part_Number: TPS565247DRLR; Mouser Part Number: 595-TPS565247DRLR; Mouser Price/Stock: https://www.mouser.co.uk/ProductDetail/Texas-Instruments/TPS565247DRLR?qs=tlsG%2FOw5FFiQ2zIpygQzLw%3D%3D; Supplier Part Number 2: 296-TPS565247DRLRCT-ND; Supplier Part Number 3: 595-TPS565247DRLR
X1 X2 = 594515: Connector Header Through Hole 40 position 0.100" (2.54mm); Manufacturer: Würth Elektronik; Automotive Qualified: No; Combined Parameters: Board-to-Board Header (male);20x2;Mounting:THT;Orient:0Â° (vertical);Shielded:No; Legacy DeviceID: AMP_68691-440HLF; PLMStatus: Active; QuickPick: 0Â° (vertical) / Board-to-Board; Second Source allowed: Yes; Style: Strip; Version Number: V01; Voltage DC (max): na; Article Number: 594515; Component Kind: Standard; ComponentLink1Description: Vendor WebSite; ComponentLink1URL: http://www.amphenolcanada.com; ComponentLink2Description: Datasheet; ComponentLink2URL: http://webnetprod.muc.infineon.com/PCBPortal_Prod/WorkFlows/AltiumComponent/StandardDocumentation?Versionid=594516; Manufacturer Order Number: 61304021121; Package Description: Connector Header Through Hole 40 position 0.100" (2.54mm); PackageReference: THT; Part Type: Connector; ROHS Compliant: Y; Supplier 2: Digikey; Supplier Part Number 2: 732-5310-ND; Temperature max: 130; Temperature min: -65; Temperature Range: Military; Type: Board-to-Board; Value: 61304021121; Library Name: AURIX_Lite_Kit_V2.0.SCHLIB
  X1: Supplier 1: Farnell; Supplier 3: Mouser
X3 = 382390_NEW: 2.1mm and 2.5mm Right Angle PCB Mount DC Power Socket 5A,12V dc, Nickel Plated; Legacy PartNumber: CON01500526; Manufacturer: Cliff; Manufacturer Order Number: FC681465P; Article Number: 382390; Automotive Qualified: No; Combined Parameters: Board-to-Cable Socket (female);-x-;Mounting:THT;Orient:90Â° (right angle);Shielded:No; Legacy DeviceID: CL-FC681465P; PLMStatus: Active; QuickPick: 90Â° (right angle) / Board-to-Cable; Second Source allowed: Yes; Symbol Type: Power Connector, Power Pins 1, 3, Normaly Closed Contact (NCC) 3, 2, 3 Pins; Value: FC681465P; Version Number: V01; Voltage DC (max): na; Component Kind: Standard; ComponentLink1Description: Vendor WebSite; ComponentLink1URL: www.cliffuk.co.uk/; ComponentLink2Description: Datasheet; ComponentLink2URL: https://www.cliffuk.co.uk/products/dcconnectors/FC681465P.pdf; Package Description: DC Power Jack, 14.5mm L X 9mm W X 11mm H body; Part Type: Connector; ROHS Compliant: Y; Style: Power Socket; Supplier 1: Farnell; Supplier 2: TME; Supplier 3: Distrelec; Supplier Part Number 1: 1854512; Temperature Range: Military; Type: Board-to-Cable; Library Name: AURIX_Lite_Kit_V2.0.SCHLIB
X301 = 382363: 6 Position Header Connector 0.100" (2.54mm) Through Hole Tin; Manufacturer: Sullins Connector Solutions; Manufacturer Order Number: PPTC061LFBN-RC; Value: PPTC061LFBN-RC; Article Number: 382363; Component Kind: Standard; Supplier Part Number 2: S7004-ND; Library Name: AURIX_Lite_Kit_V2.0.SCHLIB
X302 X304 = 382156: 8 Position Header Connector 0.100" (2.54mm) Through Hole Tin; Manufacturer: Sullins Connector Solutions; Manufacturer Order Number: PPTC081LFBN-RC; Value: PPTC081LFBN-RC; Article Number: 382156; Component Kind: Standard; Supplier Part Number 2: S7006-ND; Library Name: AURIX_Lite_Kit_V2.0.SCHLIB
X303 = 381812: 10 Position Header Connector 0.100" (2.54mm) Through Hole Tin; Manufacturer: Sullins Connector Solutions; Article Number: 381812; Component Kind: Standard; Manufacturer Order Number: PPTC101LFBN-RC; Supplier Part Number 2: S7008-ND; Value: PPTC101LFBN-RC; Library Name: AURIX_Lite_Kit_V2.0.SCHLIB
